# Altium schematic sheet MEBv1.1.SchDoc
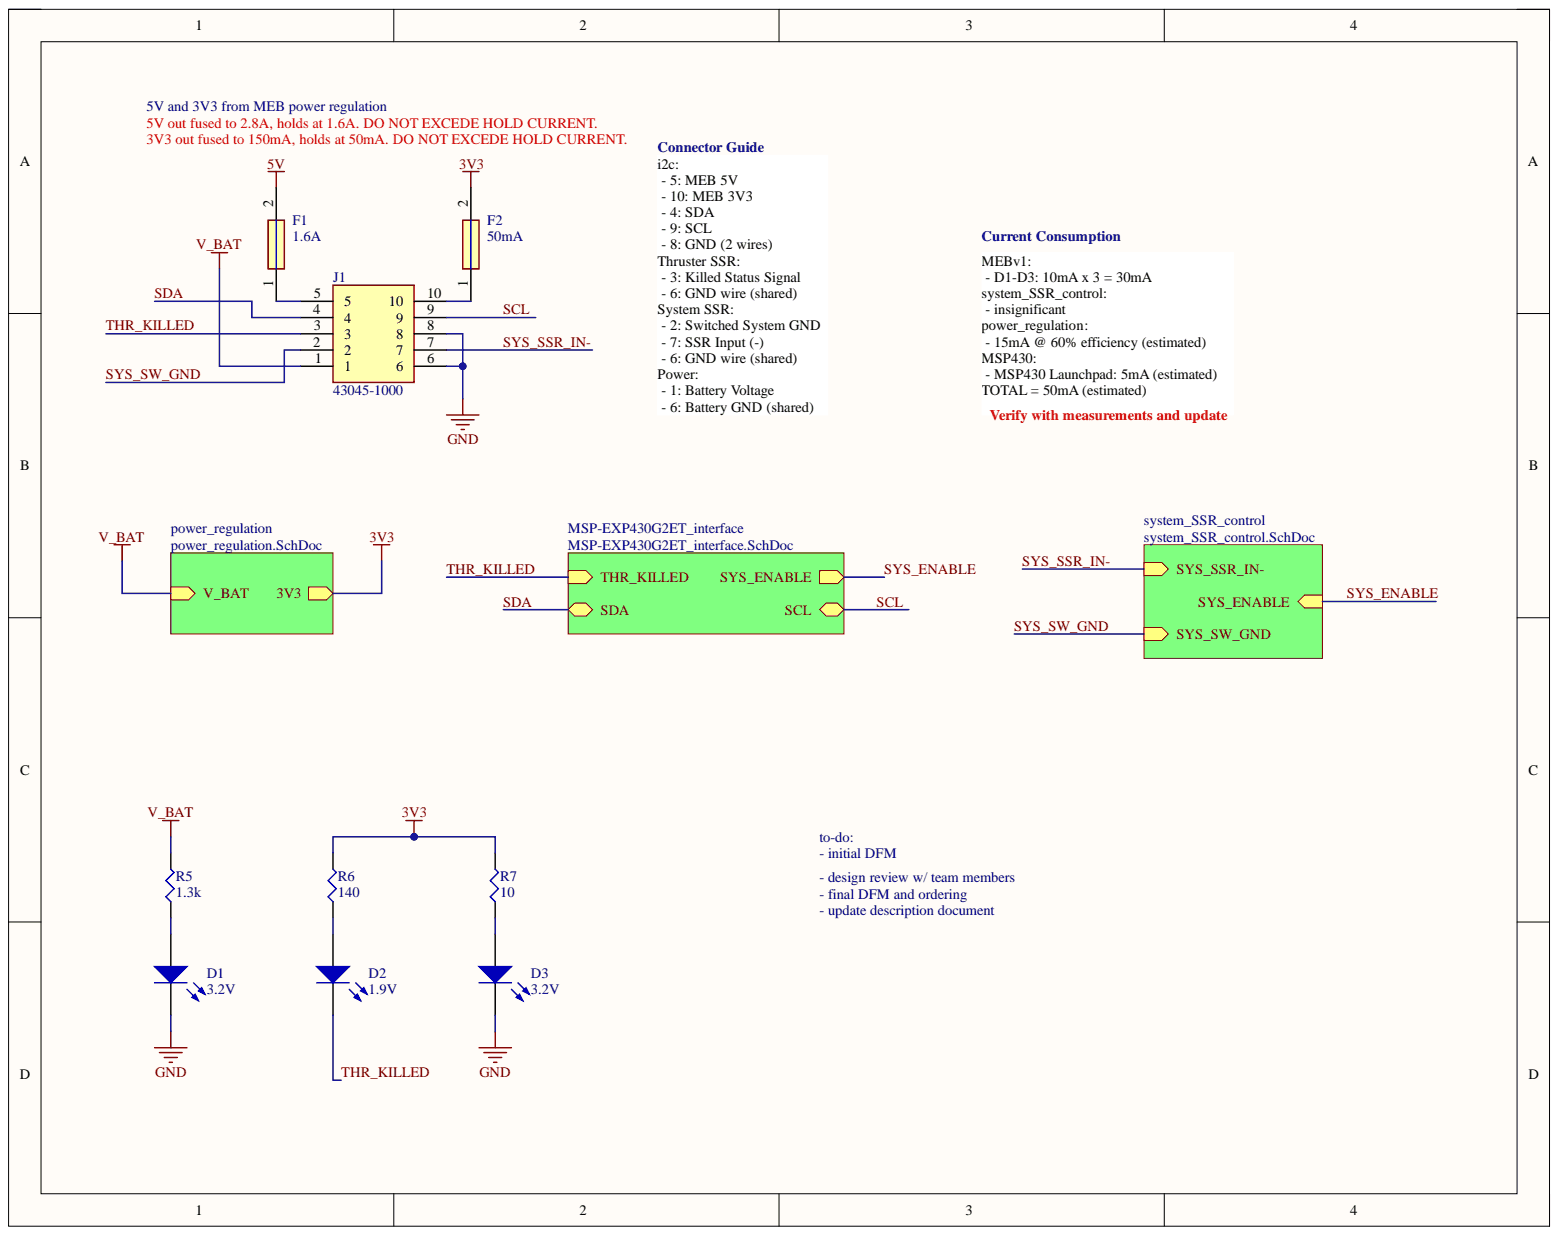
[diagram p0: MEBv1.1.SchDoc]

## Parts
D1  3.2V  [0805_A]
D2  1.9V  [0805_A]
D3  3.2V  [0805_A]
F1  1.6A  [1812]
F2  50mA  [C1210]
J1  43045-1000  [43045-1000]
R5  1.3k  [SMD-0805-RES]
R6  140  [SMD-0805-RES]
R7  10  [SMD-0805-RES]

## Nets
3V3: F2.2 R6.2 R7.2
5V: F1.2
GND: D1.1 D3.1 J1.6 J1.8
V_BAT: J1.1 R5.2
SCL: J1.9
SDA: J1.4
SYS_SSR_IN-: J1.7
SYS_SW_GND: J1.2
THR_KILLED: D2.1 J1.3
NetD1_2: D1.2 R5.1
NetD2_2: D2.2 R6.1
NetD3_2: D3.2 R7.1
NetF1_1: F1.1 J1.5
NetF2_1: F2.1 J1.10
SYS_ENABLE:

## Library data of the parts
D1 = CMP-1426-00008-1: LED BLUE CLEAR 0805 SMD; Manufacturer: Würth Elektronik; Manufacturer Part Number: 150080BS75000; Component Kind: Standard; Datasheet Link: https://www.we-online.com/katalog/datasheet/150080BS75000.pdf; Library Name: Altium Content Vault; Operating Temperature: -40°C ~ 85°C; Package / Case: 0805 (2012 Metric); RoHS: YES; Value: 3.2V
D2 = CMP-1426-00010-1: LED RED CLEAR 0805 SMD; Manufacturer: Würth Elektronik; Manufacturer Part Number: 150080SS75000; Component Kind: Standard; Datasheet Link: https://www.we-online.com/katalog/datasheet/150080SS75000.pdf; Library Name: Altium Content Vault; Operating Temperature: -40°C ~ 85°C; Package / Case: 0805 (2012 Metric); RoHS: YES; Value: 1.9V
D3 = CMP-1426-00007-1: LED GREEN CLEAR 0805 SMD; Manufacturer: Würth Elektronik; Manufacturer Part Number: 150080GS75000; Component Kind: Standard; Datasheet Link: https://www.we-online.com/katalog/datasheet/150080GS75000.pdf; Library Name: Altium Content Vault; Operating Temperature: -40°C ~ 85°C; Package / Case: 0805 (2012 Metric); RoHS: YES; Value: 3.2V
F1 = MF-MSMF160-2: PTC RESET FUSE 30V 50MA 1210; Manufacturer: Bourns Inc.; Component Kind: Standard; Datasheet Link: https://www.bourns.com/docs/Product-Datasheets/mfmsmf.pdf; Manufacturer Part Number: MF-MSMF160-2; Operating Temperature: -40°C ~ 85°C; Package / Case: 1812 (4532 Metric); RoHS: YES; Value: 1.6A
F2 = MF-MSMF160-2: PTC RESET FUSE 30V 50MA 1210; Manufacturer: Bourns Inc.; Manufacturer Part Number: MF-USMF005-2; Component Kind: Standard; Datasheet Link: https://www.bourns.com/docs/Product-Datasheets/mfusmf.pdf; Operating Temperature: -40°C ~ 85°C; Package / Case: 1210 (3225 Metric); RoHS: YES; Value: 50mA
J1 = 43045-1000: CONN HEADER R/A 10POS 3MM; Manufacturer: Molex; Manufacturer Part Number: 0430451000; Value: 600V; Component Kind: Standard; Datasheet Link: https://www.molex.com/pdm_docs/sd/430451000_sd.pdf; Library Name: URC_Lib.IntLib; Operating Temperature: -40°C ~ 105°C; Package / Case: Non-standard; RoHS: YES
R5 = ERJ-6GEYJ132V: RES 1.3K OHM 5% 1/4W 0805; Manufacturer: KOA Speer Electronics, Inc.; Manufacturer Part Number: RK73B2ATTD132J; Component Kind: Standard; Datasheet Link: https://www.koaspeer.com/pdfs/RK73B.pdf; Library Name: Panasonic_resistor_0805.IntLib; Operating Temperature: -55°C ~ 155°C; Package / Case: 0805 (2012 Metric); RoHS: YES; Value: 1.3k
R6 = ERJ-6ENF1400V: RES 140 OHM 1% 1/8W 0805; Manufacturer: YAGEO; Manufacturer Part Number: RC0805FR-07140RL; Component Kind: Standard; Datasheet Link: https://www.yageo.com/upload/media/product/productsearch/datasheet/rchip/PYu-RC_Group_51_RoHS_L_11.pdf; Library Name: Panasonic_resistor_0805.IntLib; Operating Temperature: -55°C ~ 155°C; Package / Case: 0805 (2012 Metric); RoHS: YES; Value: 140
R7 = ERJ-6ENF10R0V: RES 10 OHM 1% 1/8W 0805; Manufacturer: YAGEO; Manufacturer Part Number: RC0805FR-0710RL; Component Kind: Standard; Datasheet Link: https://www.yageo.com/upload/media/product/productsearch/datasheet/rchip/PYu-RC_Group_51_RoHS_L_11.pdf; Library Name: Panasonic_resistor_0805.IntLib; Operating Temperature: -55°C ~ 155°C; Package / Case: 0805 (2012 Metric); RoHS: YES; Value: 10
